# Altium schematic sheet 03_MCU.SchDoc
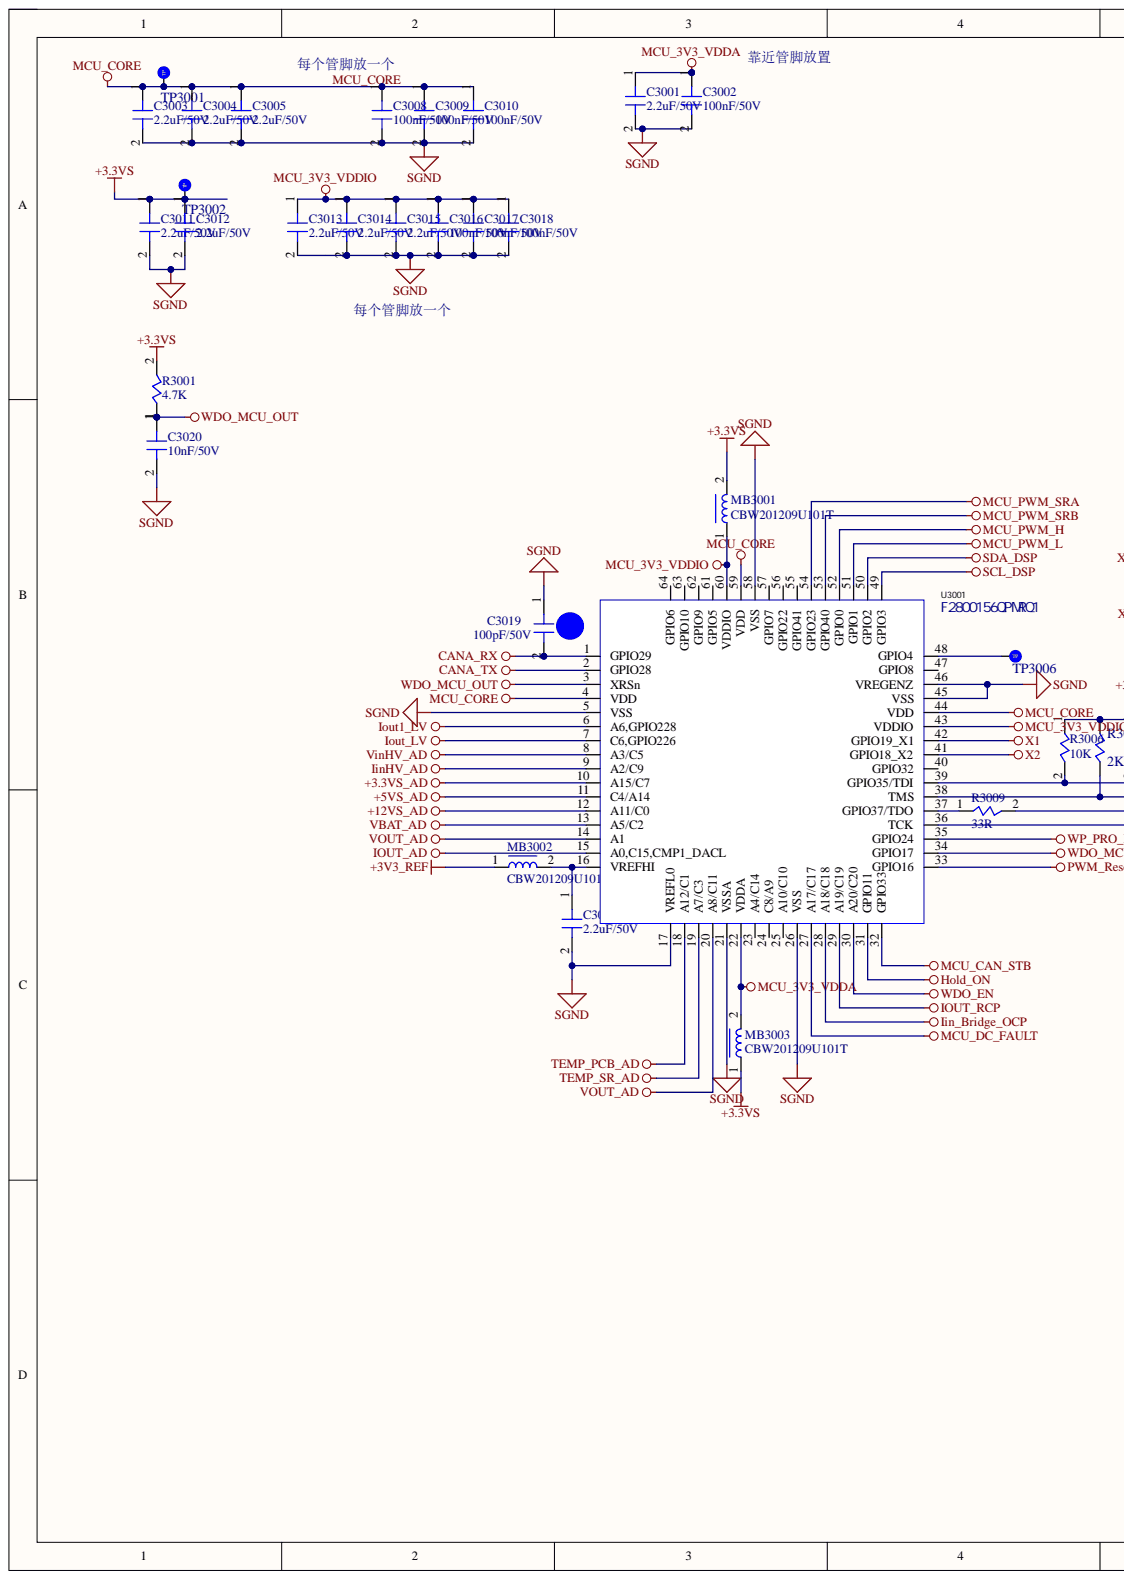
[diagram p0: 03_MCU.SchDoc, left]
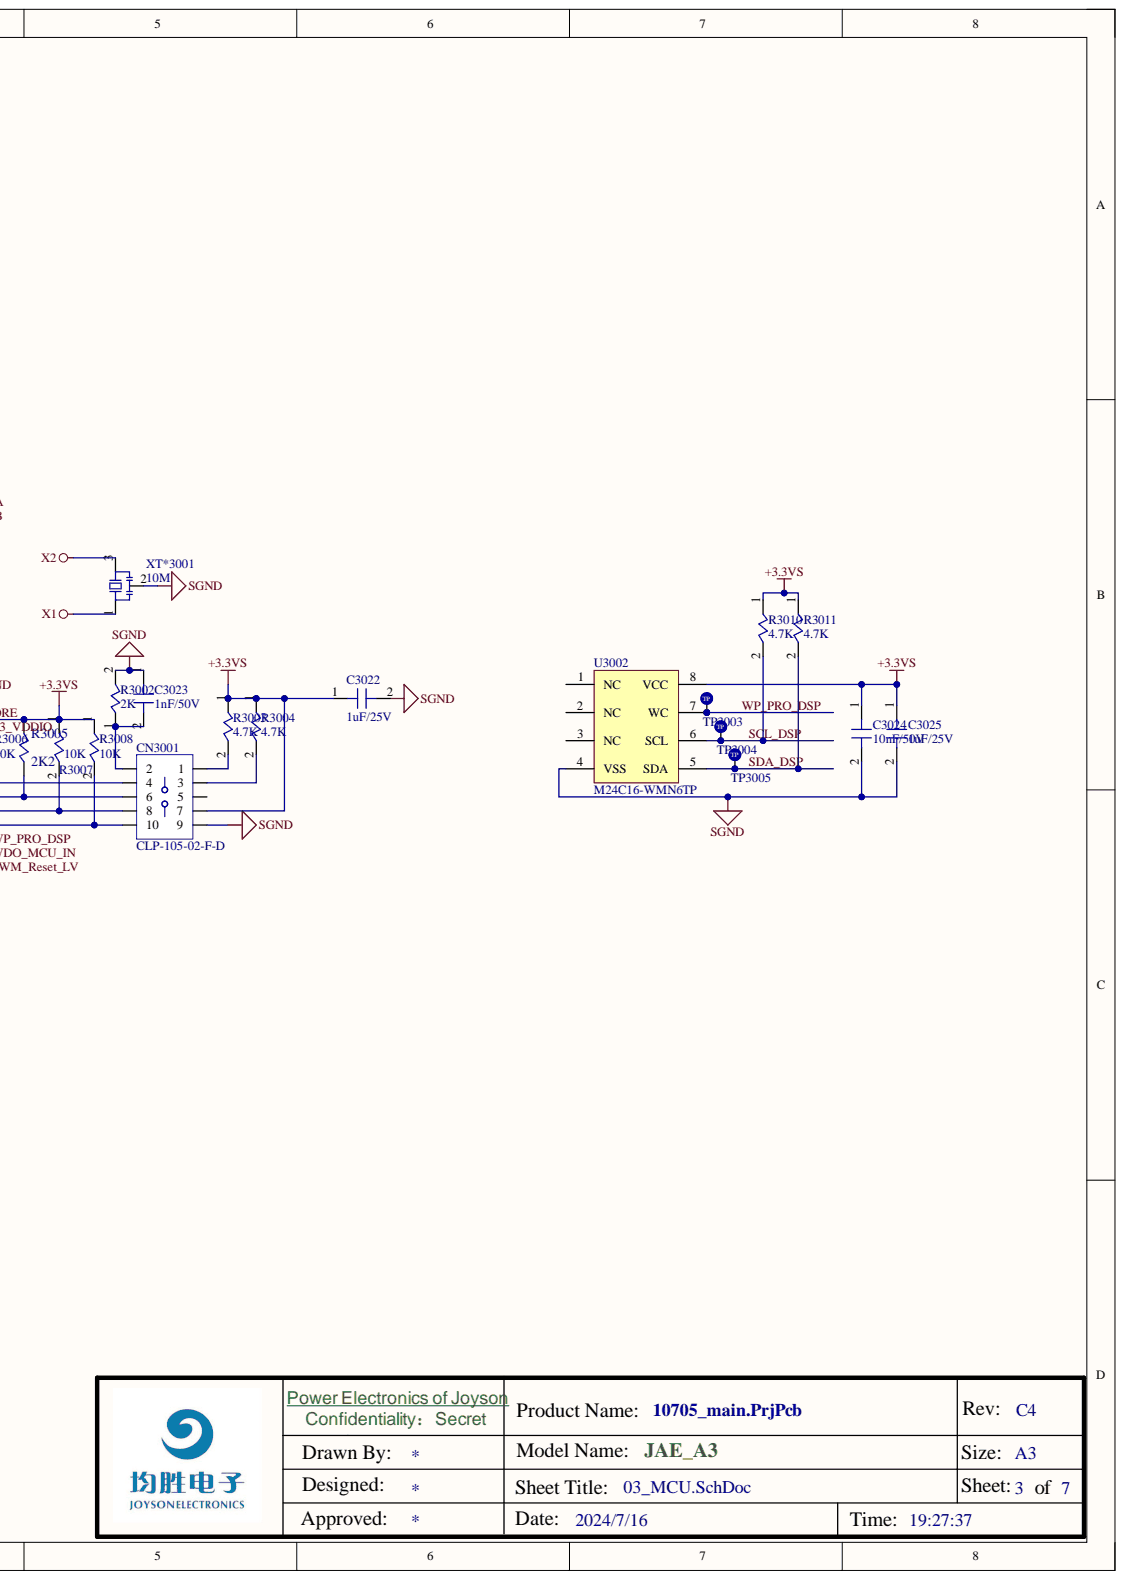
[diagram p1: 03_MCU.SchDoc, right]

## Parts
C3001  2.2uF  value 2.2uF/50V  [C-0805]
C3002  100nF  value 100nF/50V  [C-0402]
C3003  2.2uF  value 2.2uF/50V  [C-0805]
C3004  2.2uF  value 2.2uF/50V  [C-0805]
C3005  2.2uF  value 2.2uF/50V  [C-0805]
C3008  100nF  value 100nF/50V  [C-0402]
C3009  100nF  value 100nF/50V  [C-0402]
C3010  100nF  value 100nF/50V  [C-0402]
C3011  2.2uF  value 2.2uF/50V  [C-0805]
C3012  2.2uF  value 2.2uF/50V  [C-0805]
C3013  2.2uF  value 2.2uF/50V  [C-0805]
C3014  2.2uF  value 2.2uF/50V  [C-0805]
C3015  2.2uF  value 2.2uF/50V  [C-0805]
C3016  100nF  value 100nF/50V  [C-0402]
C3017  100nF  value 100nF/50V  [C-0402]
C3018  100nF  value 100nF/50V  [C-0402]
C3019  100pF  value 100pF/50V  [C-0603]
C3020  10nF  value 10nF/50V  [C-0402]
C3021  2.2uF  value 2.2uF/50V  [C-0805]
C3022  1uF  value 1uF/25V  [C-0603]
C3023  1nF  value 1nF/50V  [C-0402]
C3024  10nF  value 10nF/50V  [C-0402]
C3025  1uF  value 1uF/25V  [C-0603]
CN3001  CLP-105-02-F-D  [CLP-105-02-F-D]
MB3001  CBW201209U101T  [L-0603]
MB3002  CBW201209U101T  [L-0603]
MB3003  CBW201209U101T  [L-0603]
R3001  4.7K  [R-0402]
R3002  2K  [R-0402]
R3003  4.7K  [R-0402]
R3004  4.7K  [R-0402]
R3005  2K2  [R-0402]
R3006  10K  [R-0402]
R3007  10K  [R-0402]
R3008  10K  [R-0402]
R3009  33R  [R-0402]
R3010  4.7K  [R-0402]
R3011  4.7K  [R-0402]
TP3001  TEST PAD-0.7  [STP-0.7M]  (value not drawn)  (no BOM)
TP3002  TEST PAD-0.7  [STP-0.7M]  (value not drawn)  (no BOM)
TP3003  TP1.0  [STP-0.7M]  (value not drawn)  (no BOM)
TP3004  TP1.0  [STP-0.7M]  (value not drawn)  (no BOM)
TP3005  TP1.0  [STP-0.7M]  (value not drawn)  (no BOM)
TP3006  TEST PAD-0.7  [STP-0.7M]  (value not drawn)  (no BOM)
U3001  F2800156QPMRQ1  [LQPF-64]
U3002  M24C16-WMN6TP  [SOP-8N]
XT*3001  10M  [XTAL-3213]

## Nets
+3.3VS: C3011.1 C3012.1 C3022.1 C3024.1 C3025.1 CN3001.7 MB3001.2 MB3003.1 R3001.2 R3003.1 R3004.1 R3005.2 R3006.1 R3007.1 R3008.1 R3010.1 R3011.1 TP3002.1 U3002.8(VCC)
+3.3VS_AD: U3001.10(A15/C7)
+3V3_REF: MB3002.1
+5VS_AD: U3001.11(C4/A14)
+12VS_AD: U3001.12(A11/C0)
CANA_RX: C3019.2 U3001.1(GPIO29)
CANA_TX: U3001.2(GPIO28)
Hold_ON: U3001.31(GPIO11)
Iin_Bridge_OCP: U3001.28(A18/C18)
IinHV_AD: U3001.9(A2/C9)
Iout1_LV: U3001.6(A6,GPIO228)
IOUT_AD: U3001.15(A0,C15,CMP1_DACL)
Iout_LV: U3001.7(C6,GPIO226)
IOUT_RCP: U3001.29(A19/C19)
MCU_3V3_VDDA: C3001.1 C3002.1 MB3003.2 U3001.22(VDDA)
MCU_3V3_VDDIO: C3013.1 C3014.1 C3015.1 C3016.1 C3017.1 C3018.1 MB3001.1 U3001.43(VDDIO) U3001.60(VDDIO)
MCU_CAN_STB: U3001.32(GPIO33)
MCU_DC_FAULT: U3001.27(A17/C17)
MCU_PWM_H: U3001.52(GPIO0)
MCU_PWM_L: U3001.51(GPIO1)
MCU_PWM_SRA: U3001.54(GPIO23)
MCU_PWM_SRB: U3001.53(GPIO40)
PWM_Reset_LV: U3001.33(GPIO16)
SGND: C3001.2 C3002.2 C3003.2 C3004.2 C3005.2 C3008.2 C3009.2 C3010.2 C3011.2 C3012.2 C3013.2 C3014.2 C3015.2 C3016.2 C3017.2 C3018.2 C3019.1 C3020.2 C3021.2 C3022.2 C3023.1 C3024.2 C3025.2 CN3001.9 R3002.2 U3001.5(VSS) U3001.17(VREFL0) U3001.21(VSSA) U3001.26(VSS) U3001.45(VSS) U3001.46(VREGENZ) U3001.58(VSS) U3002.4(VSS) XT*3001.2
TEMP_PCB_AD: U3001.18(A12/C1)
TEMP_SR_AD: U3001.19(A7/C3)
VBAT_AD: U3001.13(A5/C2)
VinHV_AD: U3001.8(A3/C5)
VOUT_AD: U3001.14(A1) U3001.20(A8/C11)
WDO_EN: U3001.30(A20/C20)
WDO_MCU_IN: U3001.34(GPIO17)
WDO_MCU_OUT: C3020.1 R3001.1 U3001.3(XRSn)
X1: U3001.42(GPIO19_X1) XT*3001.1
X2: U3001.41(GPIO18_X2) XT*3001.3
MCU_CORE: C3003.1 C3004.1 C3005.1 C3008.1 C3009.1 C3010.1 TP3001.1 U3001.4(VDD) U3001.44(VDD) U3001.59(VDD)
SCL_DSP: R3010.2 TP3004.1 U3001.49(GPIO3) U3002.6(SCL)
SDA_DSP: R3011.2 TP3005.1 U3001.50(GPIO2) U3002.5(SDA)
WP_PRO_DSP: TP3003.1 U3001.35(GPIO24) U3002.7(WC)
NetC3021_1: C3021.1 MB3002.2 U3001.16(VREFHI)
NetC3023_2: C3023.2 CN3001.2 R3002.1
NetCN3001_1: CN3001.1 R3003.2
NetCN3001_3: CN3001.3 R3004.2
NetCN3001_4: CN3001.4 R3006.2 U3001.39(GPIO35/TDI)
NetCN3001_6: CN3001.6 R3005.1 U3001.38(TMS)
NetCN3001_8: CN3001.8 R3007.2 R3009.2
NetCN3001_10: CN3001.10 R3008.2 U3001.36(TCK)
NetR3009_1: R3009.1 U3001.37(GPIO37/TDO)
NetTP3006_1: TP3006.1 U3001.48(GPIO4)

Not connected: CN3001 5; U3001 23(A4/C14) 24(C8/A9) 25(A10/C10) 40(GPIO32) 47(GPIO8) 55(GPIO41) 56(GPIO22) 57(GPIO7) 61(GPIO5) 62(GPIO9) 63(GPIO10) 64(GPIO6); U3002 1(NC) 2(NC) 3(NC)

## Library data of the parts
C3001 C3003-C3005 C3011-C3015 C3021 = 1101032037-00: 贴片电容封装; Brand: YAGEO; Database Table Name: Capacitor-SMD; Grade: 汽车级; Material Name: Capacitor; Spec/Modle: Capacitor-MLCC-0805-X7R-±10%-50V-2.2uF; Temprature: -55℃ to +125℃; Type: 贴片; Vendor No: AC0805KKX7R9BB225; Component Kind: Standard; Library Name: Database_Libs1.DbLib; Material No: 1101032037-00; Value2: 2.2uF
C3002 C3008-C3010 C3016-C3018 = 1101012028-00: 贴片电容封装; Brand: YAGEO; Database Table Name: Capacitor-SMD; Grade: 汽车级; Material Name: Capacitor; SAP Number: 09701-715/0000; Spec/Modle: Capacitor-MLCC-0402-X7R-±10%-50V-100nF; Temprature: -55℃ to +125℃; Type: 贴片; Vendor No: AC0402KRX7R9BB104; Component Kind: Standard; Library Name: Database_Libs1.DbLib; Material No: 1101012028-00; Value2: 100nF
C3019 = 1101022001-00: 贴片电容封装; Brand: YAGEO; Database Table Name: Capacitor-SMD; Grade: 汽车级; Material Name: Capacitor; Spec/Modle: Capacitor-MLCC-0603-X7R-±10%-50V-100pF; Temprature: -55℃ to +125℃; Type: 贴片; Vendor No: AC0603KRX7R9BB101; Component Kind: Standard; Library Name: Database_Libs1.DbLib; Material No: 1101022001-00; Value2: 100pF
C3020 C3024 = 1101012019-00: 贴片电容封装; Brand: YAGEO; Database Table Name: Capacitor-SMD; Grade: 汽车级; Material Name: Capacitor; SAP Number: 09701-712/0000; Spec/Modle: Capacitor-MLCC-0402-X7R-±10%-50V-10nF; Temprature: -55℃ to +125℃; Type: 贴片; Vendor No: AC0402KRX7R9BB103; Component Kind: Standard; Library Name: Database_Libs1.DbLib; Material No: 1101012019-00; Value2: 10nF
C3022 C3025 = 1101022035-00: 贴片电容封装; Brand: YAGEO; Database Table Name: Capacitor-SMD; Grade: 汽车级; Material Name: Capacitor; Spec/Modle: Capacitor-MLCC-0603-X7R-±10%-25V-1uF; Temprature: -55℃ to +125℃; Type: 贴片; Vendor No: AC0603KPX7R8BB105; Component Kind: Standard; Library Name: Database_Libs1.DbLib; Material No: 1101022035-00; Value2: 1uF
C3023 = 1101012010-00: 贴片电容封装; Brand: YAGEO; Database Table Name: Capacitor-SMD; Grade: 汽车级; Material Name: Capacitor; SAP Number: 09801-025/0000; Spec/Modle: Capacitor-MLCC-0402-X7R-±10%-50V-1nF; Temprature: -55℃ to +125℃; Type: 贴片; Vendor No: AC0402KRX7R9BB102; Component Kind: Standard; Library Name: Database_Libs1.DbLib; Material No: 1101012010-00; Value2: 1nF
CN3001 = 1603311002-00: Brand: SAMTEC; Database Table Name: Connector; Grade: 工业级; Temprature: -55℃ to +125℃; Component Kind: Standard; Library Name: Database_Libs1.DbLib; Material No: 1603311002-00; Type: 插件; Value: CLP-105-02-F-D; Vendor No: CLP-105-02-F-D
MB3001-MB3003 = 1503010002-00: 磁珠封装; Brand: 风华; Database Table Name: Magnetic; Grade: 工业级; Material Name: Inductor; Spec/Modle: Inductor-Magnetic bead-0603-±25%-100Ω; Temprature: -40℃ to +85℃; Component Kind: Standard; Library Name: Database_Libs1.DbLib; Material No: 1503010002-00; Type: 贴片; Value: CBW201209U101T; Vendor No: CBW201209U101T
R3001 R3003 R3004 R3010 R3011 = 1001010419-00: 贴片电阻封装; Brand: YAGEO; Database Table Name: Resistor-SMD; Grade: 汽车级; Material Name: Resistor; SAP Number: 05544-439/0000; Spec/Modle: Resistor-SMD-0402-1/16W-±1%-±100ppm/℃-4.7KΩ; Temprature: -55℃ to +155℃; Vendor No: AC0402FR-074K7L; Component Kind: Standard; Library Name: Database_Libs1.DbLib; Material No: 1001010419-00; Type: 贴片; Value: 4.7K
R3002 = 1001010375-00: 贴片电阻封装; Brand: YAGEO; Database Table Name: Resistor-SMD; Grade: 汽车级; Material Name: Resistor; SAP Number: 05544-395/0000; Spec/Modle: Resistor-SMD-0402-1/16W-±1%-±100ppm/℃-2KΩ; Temprature: -55℃ to +155℃; Vendor No: AC0402FR-072KL; Component Kind: Standard; Library Name: Database_Libs1.DbLib; Material No: 1001010375-00; Type: 贴片; Value: 2K
R3005 = RES-0402: Brand: YAGEO; Material Name: Resistor; Material No: 2010101340-00; SAP Number: 06005-297/0000; Spec/Modle: SMD,RES,0402,10K,F,1/16W; Vendor No: AC0402FR-0710KL; Component Kind: Standard; Library Name: my lib.schlib
R3006-R3008 = 1001010457-00: 贴片电阻封装; Brand: YAGEO; Database Table Name: Resistor-SMD; Grade: 汽车级; Material Name: Resistor; SAP Number: 05544-477/0000; Spec/Modle: Resistor-SMD-0402-1/16W-±1%-±100ppm/℃-10KΩ; Temprature: -55℃ to +155℃; Vendor No: AC0402FR-0710KL; Component Kind: Standard; Library Name: Database_Libs1.DbLib; Material No: 1001010457-00; Type: 贴片; Value: 10K
R3009 = 1001010172-00: 贴片电阻封装; Brand: YAGEO; Database Table Name: Resistor-SMD; Grade: 汽车级; Material Name: Resistor; SAP Number: 05544-192/0000; Spec/Modle: Resistor-SMD-0402-1/16W-±1%-±100ppm/℃-33Ω; Temprature: -55℃ to +155℃; Vendor No: AC0402FR-0733RL; Component Kind: Standard; Library Name: Database_Libs1.DbLib; Material No: 1001010172-00; Type: 贴片; Value: 33R
TP3003-TP3005 = TP: Library Name: my lib.schlib
U3001 = 1406040003-00: Brand: TI; Database Table Name: IC; Grade: 汽车级; Spec/Modle: IC-DSP-LQFP64-32bit-120MHz-256KB-VCC(3.3V)-mark(00155QPNQF28); Temprature: -40℃ to +125℃; Component Kind: Standard; Library Name: Database_Libs1.DbLib; Material Name: IC; Material No: 1406040003-00; Type: 贴片; Value: F2800156QPMRQ1; Vendor No: F2800156QPMRQ1
U3002 = 1409010003-00: 芯片 16 Kbit串行I2C总线EEPROM-SO8; Brand: ST; Database Table Name: IC; Grade: 工业级; Spec/Modle: IC-EEPROM-SO8-16kbit-400kHz-VCC(2.5-5.5V); Temprature: -40℃ to +125℃; Component Kind: Standard; Library Name: Database_Libs1.DbLib; Material Name: IC; Material No: 1409010003-00; Type: 贴片; Value: M24C16-WMN6TP; Vendor No: M24C16-WMN6TP
XT*3001 = 1902010008-00: 晶振3pin封装; Brand: MURATA; Database Table Name: Other; Grade: 汽车级; Material name: OSC; Order code: CSTNE10M0G52A000R0; SAP Number: 06005-397/0000; Specification: XTAL-SMD3.2*1.3mm-10M-±0.50%-10pF; Temprature: -40℃ to +125℃; Component Kind: Standard; Library Name: Database_Libs1.DbLib; Material No: 1902010008-00; Type: 贴片; Value: 10M
TP3001 TP3002 TP3006 = TEST PAD-0.7: Library Name: Test Pad.SchLib
